# Altium schematic sheet WIFI_XR829Q.SchDoc(WIFI_XR829Q)
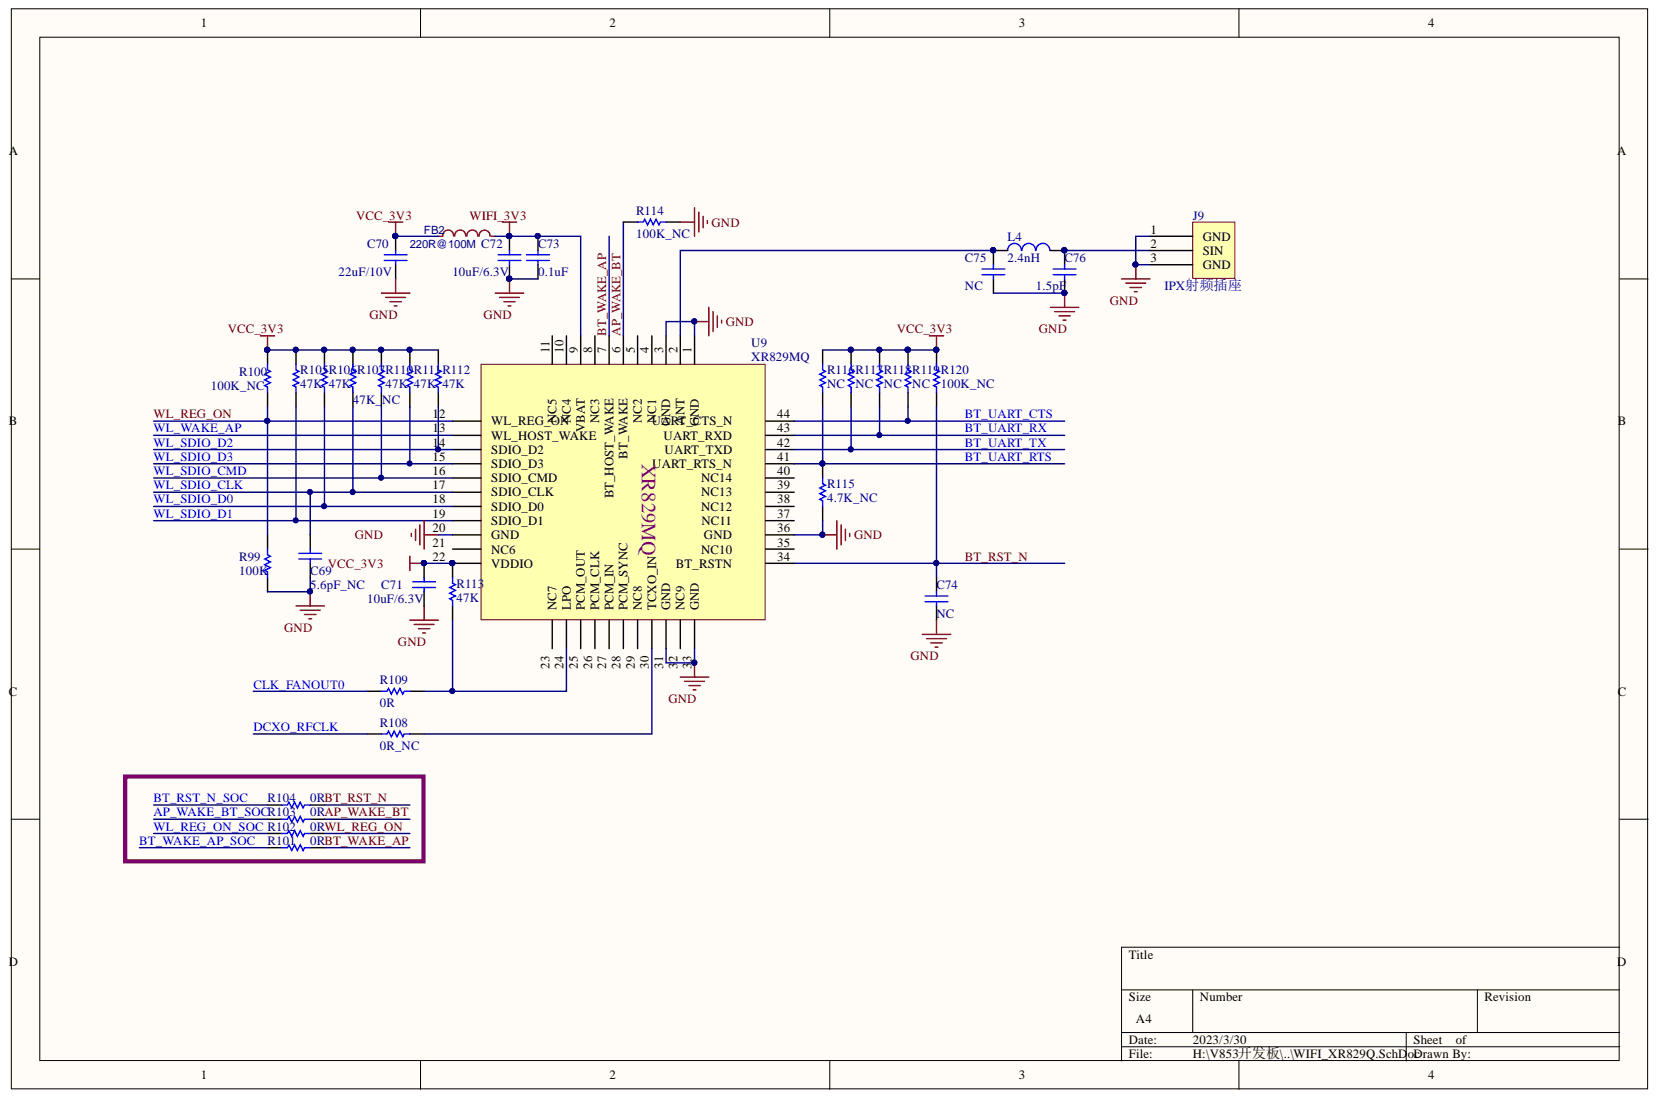
[diagram p0: WIFI_XR829Q.SchDoc]

## Parts
C69  5.6pF_NC  [0402]
C70  22uF/10V  [0603]
C71  10uF/6.3V  [0402]
C72  10uF/6.3V  [0402]
C73  0.1uF  [0402]
C74  NC  [0402]
C75  NC  [0402]
C76  1.5pF  [0402]
FB2  220R@100M  [0603]
J9  U.FL-R-SMT-1(80)  [IPX_RECEPTACLE]  (value not drawn)
L4  2.4nH  [0402]
R99  100K  [0402]
R100  100K_NC  [0402]
R101  0R  [0402]
R102  0R  [0402]
R103  0R  [0402]
R104  0R  [0402]
R105  47K  [0402]
R106  47K  [0402]
R107  47K_NC  [0402]
R108  0R_NC  [0402]
R109  0R  [0402]
R110  47K  [0402]
R111  47K  [0402]
R112  47K  [0402]
R113  47K  [0402]
R114  100K_NC  [0402]
R115  4.7K_NC  [0402]
R116  NC  [0402]
R117  NC  [0402]
R118  NC  [0402]
R119  NC  [0402]
R120  100K_NC  [0402]
U9  XR829MQ  [XR829MQ]

## Nets
GND: C69.1 C70.1 C71.1 C72.1 C73.1 C74.1 C75.1 C76.1 J9.1 J9.3 R99.1 R114.1 R115.1 U9.1 U9.3 U9.20(GND) U9.31(GND) U9.33(GND) U9.36
VCC_3V3: C70.2 C71.2 FB2.1 R100.2 R105.2 R106.2 R107.2 R110.2 R111.2 R112.2 R113.2 R116.2 R117.2 R118.2 R119.2 R120.2 U9.22(VDDIO)
WIFI_3V3: C72.2 C73.2 FB2.2 U9.9
AP_WAKE_BT: R103.1 R114.2 U9.6
AP_WAKE_BT_SOC: R103.2
BT_RST_N: C74.2 R104.1 R120.1 U9.34
BT_RST_N_SOC: R104.2
BT_UART_CTS: R119.1 U9.44
BT_UART_RTS: R115.2 R116.1 U9.41
BT_UART_RX: R118.1 U9.43
BT_UART_TX: R117.1 U9.42
BT_WAKE_AP: R101.1 U9.7
BT_WAKE_AP_SOC: R101.2
CLK_FANOUT0: R109.2
DCXO_RFCLK: R108.2
WL_REG_ON: R99.2 R100.1 R102.1 U9.12(WL_REG_ON)
WL_REG_ON_SOC: R102.2
WL_SDIO_CLK: C69.2 R107.1 U9.17(SDIO_CLK)
WL_SDIO_CMD: R110.1 U9.16(SDIO_CMD)
WL_SDIO_D0: R106.1 U9.18(SDIO_D0)
WL_SDIO_D1: R105.1 U9.19(SDIO_D1)
WL_SDIO_D2: R112.1 U9.14(SDIO_D2)
WL_SDIO_D3: R111.1 U9.15(SDIO_D3)
WL_WAKE_AP: U9.13(WL_HOST_WAKE)
NetC75_2: C75.2 L4.1 U9.2
NetC76_2: C76.2 J9.2 L4.2
NetR108_1: R108.1 U9.30(TCXO_IN)
NetR109_1: R109.1 R113.1 U9.24(LPO)

Not connected: U9 4 5 8 10 11 21(NC6) 23(NC7) 25(PCM_OUT) 26(PCM_CLK) 27(PCM_IN) 28(PCM_SYNC) 29(NC8) 32(NC9) 35 37 38 39 40

## Library data of the parts
C69-C76 = Cap: Capacitor; PartNumber: CAP100NF; Component Kind: Standard; LatestRevisionDate: 17-Jul-2002; LatestRevisionNote: Re-released for DXP Platform.; Library Name: Miscellaneous Devices.IntLib; PackageReference: 0402; Published: 8-Jun-2000; Signal Integrity: Cap; Simulation: CAP; Publisher: Altium Limited
  C69: Value: 5.6pF_NC
  C70: Value: 22uF/10V
  C71 C72: Value: 10uF/6.3V
  C73: Value: 0.1uF
  C74 C75: Value: NC
  C76: Value: 1.5pF
FB2 = (no symbol name): BOM_Manufacturer: MuRata; BOM_Manufacturer Part: BLM18KG221SN1D; nameAlias: Value; BOM_JLCPCB Part Class: Extended Part; BOM_Supplier: LCSC; BOM_Supplier Part: C88980; Component Kind: Standard; spicePre: L; spiceSymbolName: BLM18KG221SN1D
J9 = IPX_RECEPTACLE: VALUE: IPX射频插座; Component Kind: Standard; JLC NO: C88374; Library Name: HM2.SchLib
L4 = Inductor: Component Kind: Standard; Library Name: MyDevice.SchLib; Value: 2.4nH
R99-R120 = Res1: Resistor; PartNumber: RES2.2K; Component Kind: Standard; LatestRevisionDate: 17-Jul-2002; LatestRevisionNote: Re-released for DXP Platform.; Library Name: Miscellaneous Devices.IntLib; PackageReference: 0402; Published: 8-Jun-2000; Signal Integrity: Res; Simulation: RESISTOR; Publisher: Altium Limited
  R99: Value: 100K
  R100 R114 R120: Value: 100K_NC
  R101-R104 R109: Value: 0R
  R105 R106 R110-R113: Value: 47K
  R107: Value: 47K_NC
  R108: Value: 0R_NC
  R115: Value: 4.7K_NC
  R116-R119: Value: NC
U9 = XR829MQ: Component Kind: Standard; Library Name: HM2.SchLib
